# Altium schematic sheet 6 Power.SchDoc
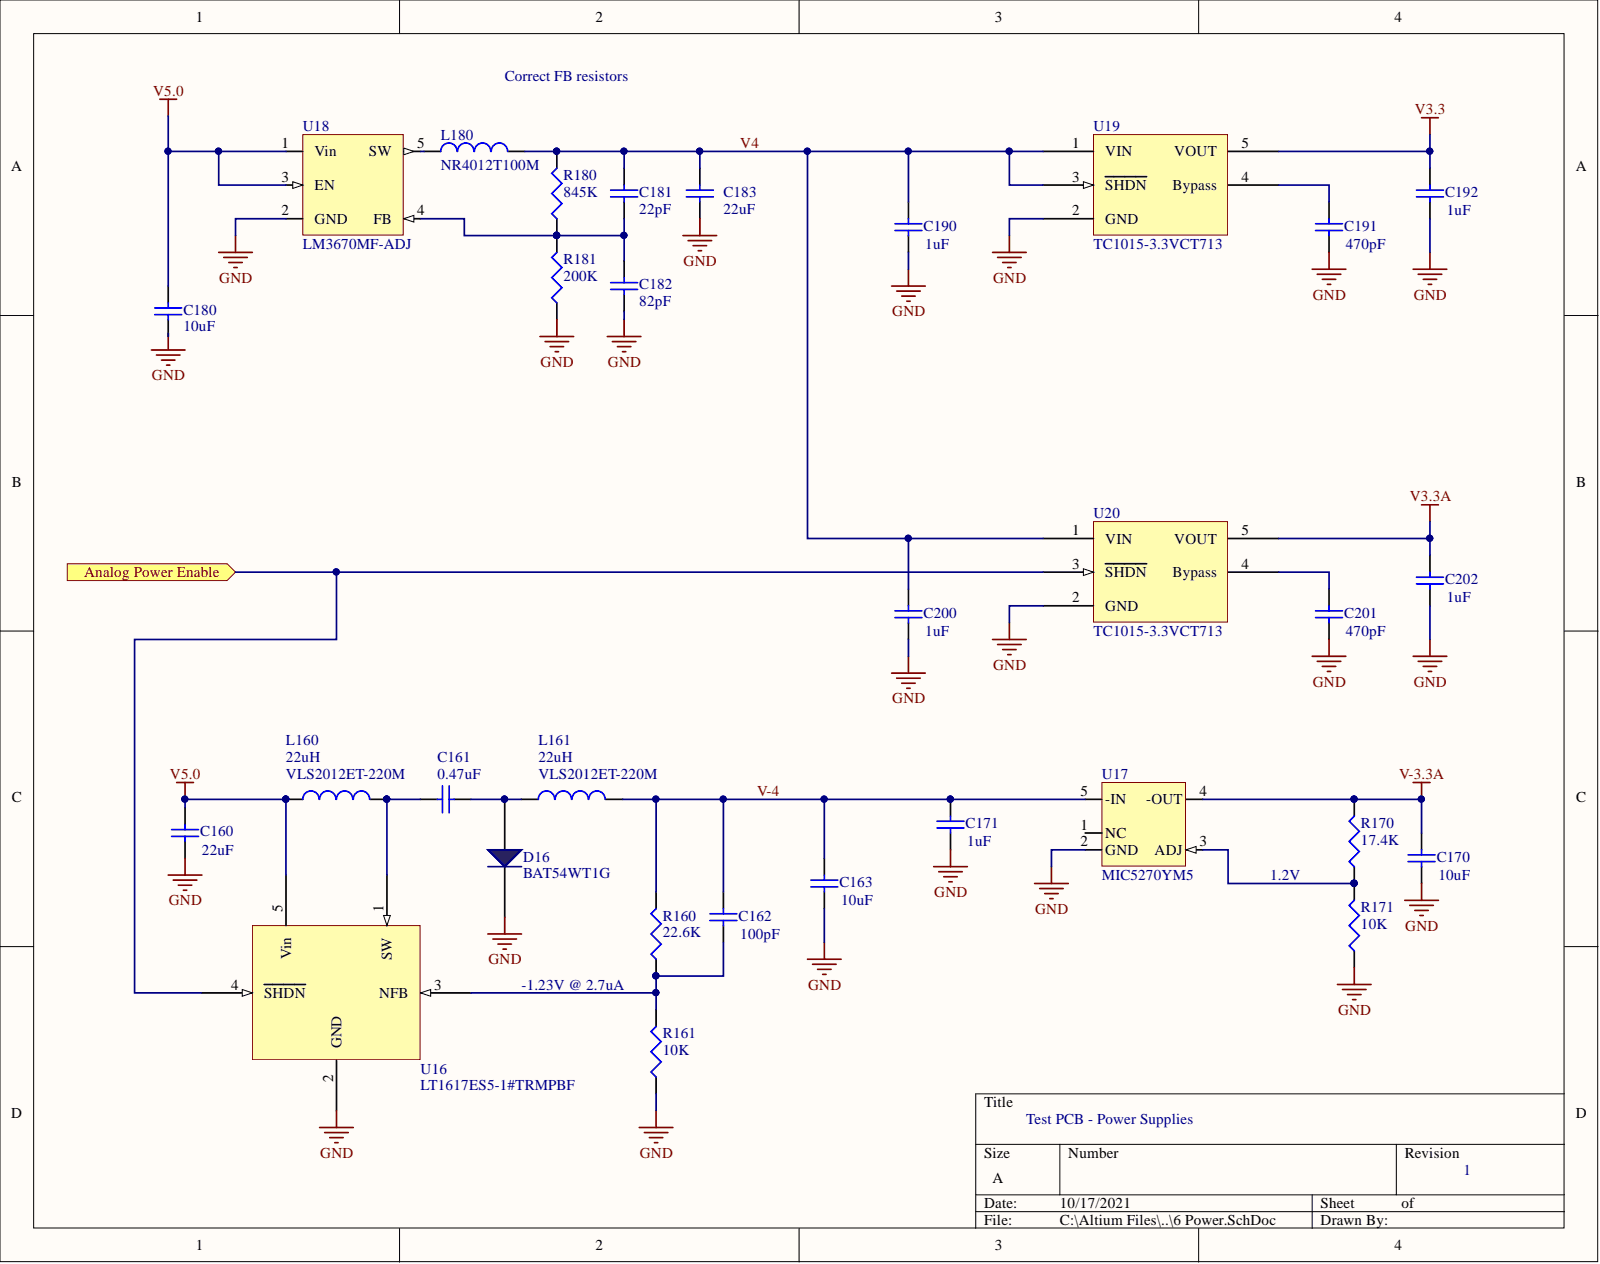
[diagram p0: 6 Power.SchDoc]

## Parts
C160  22uF  [C0805]
C161  0.47uF  [C0603]
C162  100pF  [C0603]
C163  10uF  [C0805]
C170  10uF  [C0805]
C171  1uF  [C0603]
C180  10uF  [C0805]
C181  22pF  [C0603]
C182  82pF  [C0603]
C183  22uF  [C0805]
C190  1uF  [C0603]
C191  470pF  [C0603]
C192  1uF  [C0603]
C200  1uF  [C0603]
C201  470pF  [C0603]
C202  1uF  [C0603]
D16  BAT54WT1G  [SC70-3]
L160  VLS2012ET-220M  value 22uH  [L_2012]
L161  VLS2012ET-220M  value 22uH  [L_2012]
L180  NR4012T100M  [L_4018]
R160  22.6K  [R0603]
R161  10K  [R0603]
R170  17.4K  [R0603]
R171  10K  [R0603]
R180  845K  [R0603]
R181  200K  [R0603]
U16  LT1617ES5-1#TRMPBF  [SOT23-5]
U17  MIC5270YM5  [SOT23-5]
U18  LM3670MF-ADJ  [SC70-5]
U19  TC1015-3.3VCT713  [SOT23-5]
U20  TC1015-3.3VCT713  [SOT23-5]

## Ports
Analog Power Enable

## Nets
GND: C160.1 C163.1 C170.1 C171.1 C180.1 C182.1 C183.1 C190.1 C191.1 C192.1 C200.1 C201.1 C202.1 D16.3 R161.1 R171.1 R181.1 U16.2(GND) U17.2(GND) U18.2(GND) U19.2(GND) U20.2(GND)
V3.3: C192.2 U19.5(VOUT)
V3.3A: C202.2 U20.5(VOUT)
V5.0: C160.2 C180.2 L160.1 U16.5(Vin) U18.1(Vin) U18.3(EN)
V-3.3A: C170.2 R170.2 U17.4(-OUT)
Analog Power Enable: U16.4(SHDN) U20.3(SHDN) ; port Analog Power Enable
V4: C181.2 C183.2 C190.2 C200.2 L180.2 R180.2 U19.1(VIN) U19.3(SHDN) U20.1(VIN)
V-4: C162.2 C163.2 C171.2 L161.2 R160.2 U17.5(-IN)
NetC161_1: C161.1 L160.2 U16.1(SW)
NetC161_2: C161.2 D16.1 L161.1
NetC162_1: C162.1 R160.1 R161.2 U16.3(NFB)
NetC181_1: C181.1 C182.2 R180.1 R181.2 U18.4(FB)
NetC191_2: C191.2 U19.4(Bypass)
NetC201_2: C201.2 U20.4(Bypass)
NetL180_1: L180.1 U18.5(SW)
NetR170_1: R170.1 R171.2 U17.3(ADJ)

Not connected: U17 1(NC)

## Library data of the parts
C160-C163 C170 C171 C180-C183 C190-C192 C200-C202 = Cap SM: Capacitor, SM; Component Kind: Standard; LatestRevisionDate: 29-May-2009; LatestRevisionNote: IPC-7351 Footprint Added.; PackageDescription: Chip Capacitor; PackageReference: C1206; Published: 8-Jun-2000; Signal Integrity: Cap Semi; Simulation: CAP; Library Name: Graylark.IntLib; Publisher: Altium Limited
  C160 C183: Value: 22uF
  C161: Value: 0.47uF
  C162: Value: 100pF
  C163 C170 C180: Value: 10uF
  C171 C190 C192 C200 C202: Value: 1uF
  C181: Value: 22pF
  C182: Value: 82pF
  C191 C201: Value: 470pF
D16 = BAT54W: Component Kind: Standard; Library Name: Graylark.IntLib
L160 L161 L180 = Inductor: Component Kind: Standard; Library Name: Graylark.IntLib
R160 R161 R170 R171 R180 R181 = Res SM: Resistor; Component Kind: Standard; LatestRevisionDate: 29-May-2009; LatestRevisionNote: IPC-7351 Footprint Added.; PackageDescription: Chip Resistor; PackageReference: J1-0603; Published: 8-Jun-2000; Signal Integrity: Res; Simulation: RESISTOR; Library Name: Graylark.IntLib; Publisher: Altium Limited
  R160: Value: 22.6K
  R161 R171: Value: 10K
  R170: Value: 17.4K
  R180: Value: 845K
  R181: Value: 200K
U16 = LT1617-1: Component Kind: Standard; Library Name: Graylark.IntLib
U17 = MIC5270BM5: Component Kind: Standard; Library Name: Graylark.IntLib
U18 = LM3670MFX-ADJ: Component Kind: Standard; Library Name: Graylark.IntLib
U19 U20 = TC1014: Component Kind: Standard; Library Name: Graylark.IntLib
